# Altium schematic sheet 06_fmu_mcu3.SchDoc(06_fmu_mcu3)
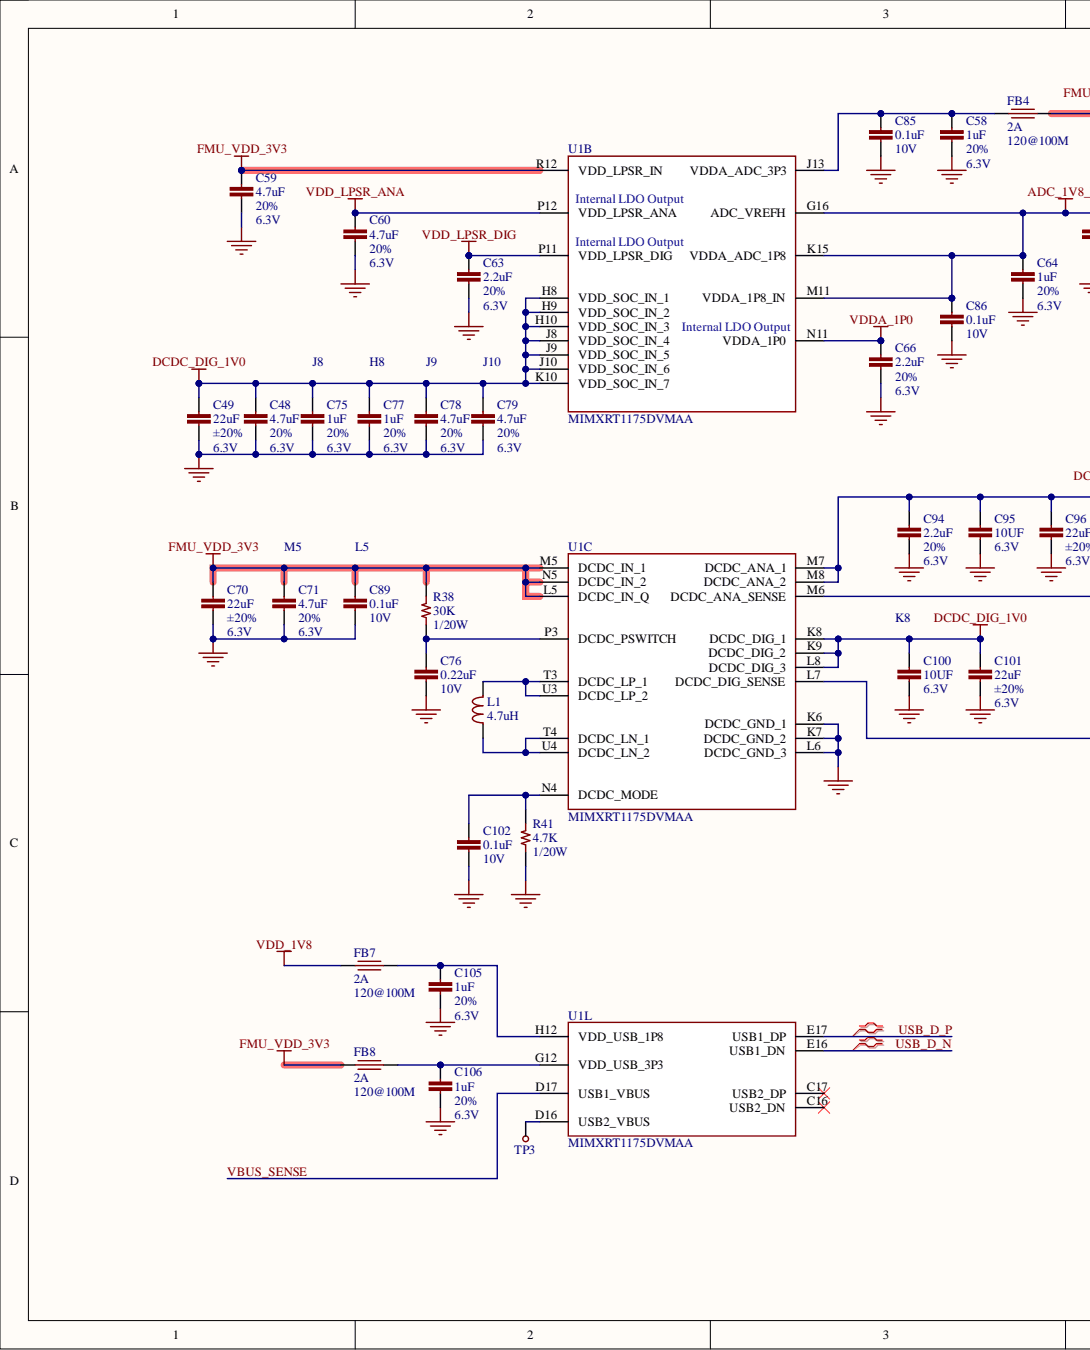
[diagram p0: 06_fmu_mcu3.SchDoc, left]
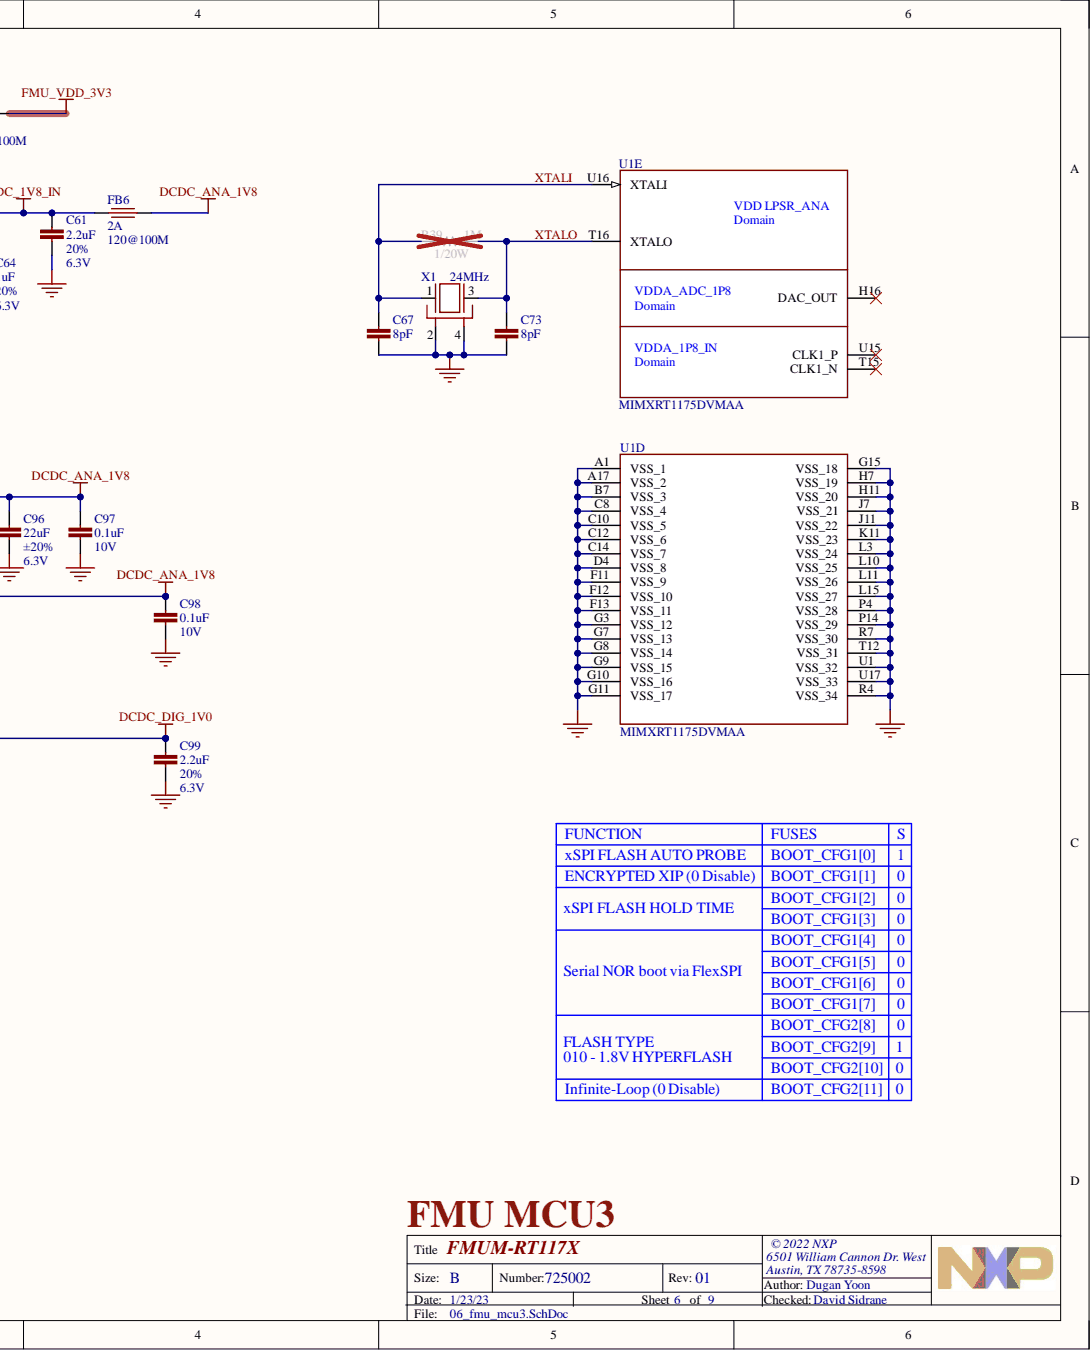
[diagram p1: 06_fmu_mcu3.SchDoc, right]

## Parts
C48  value 4.7uF  Tolerance: 20%  Voltage - Rated: 6.3V  [CAPC60X30X33L15N]  (hidden comment: CAP CER 4.7uF 20% 10V X5R 0201)
C49  value 22uF  Tolerance: ±20%  Voltage - Rated: 6.3V  [CAPC100X50X56L25N_NO_SILK]  (hidden comment: CAP CER 22UF 20% 6.3V X5R 0402)
C58  value 1uF  Tolerance: 20%  Voltage - Rated: 6.3V  [CAPC60X30X33L15N]  (hidden comment: CAP CER 1uF 20% 10V X5R 0201)
C59  value 4.7uF  Tolerance: 20%  Voltage - Rated: 6.3V  [CAPC60X30X33L15N]  (hidden comment: CAP CER 4.7uF 20% 10V X5R 0201)
C60  value 4.7uF  Tolerance: 20%  Voltage - Rated: 6.3V  [CAPC60X30X33L15N]  (hidden comment: CAP CER 4.7uF 20% 10V X5R 0201)
C61  value 2.2uF  Tolerance: 20%  Voltage - Rated: 6.3V  [CAPC60X30X33L15N]  (hidden comment: CAP CER 2.2uF 20% 10V X5R 0201)
C63  value 2.2uF  Tolerance: 20%  Voltage - Rated: 6.3V  [CAPC60X30X33L15N]  (hidden comment: CAP CER 2.2uF 20% 10V X5R 0201)
C64  value 1uF  Tolerance: 20%  Voltage - Rated: 6.3V  [CAPC60X30X33L15N]  (hidden comment: CAP CER 1uF 20% 10V X5R 0201)
C66  value 2.2uF  Tolerance: 20%  Voltage - Rated: 6.3V  [CAPC60X30X33L15N]  (hidden comment: CAP CER 2.2uF 20% 10V X5R 0201)
C67  value 8pF  [CAPC60X30X33L15N]  (hidden comment: CAP CER 8PF +/-0.5PF 50V COG/NPO 0201)
C70  value 22uF  Tolerance: ±20%  Voltage - Rated: 6.3V  [CAPC100X50X56L25N_NO_SILK]  (hidden comment: CAP CER 22UF 20% 6.3V X5R 0402)
C71  value 4.7uF  Tolerance: 20%  Voltage - Rated: 6.3V  [CAPC60X30X33L15N]  (hidden comment: CAP CER 4.7uF 20% 10V X5R 0201)
C73  value 8pF  [CAPC60X30X33L15N]  (hidden comment: CAP CER 8PF +/-0.5PF 50V COG/NPO 0201)
C75  value 1uF  Tolerance: 20%  Voltage - Rated: 6.3V  [CAPC60X30X33L15N]  (hidden comment: CAP CER 1uF 20% 10V X5R 0201)
C76  value 0.22uF  Voltage - Rated: 10V  [CAPC60X30X33L15N]  (hidden comment: CAP CER 0.22uF 10% 10V X5R 0201)
C77  value 1uF  Tolerance: 20%  Voltage - Rated: 6.3V  [CAPC60X30X33L15N]  (hidden comment: CAP CER 1uF 20% 10V X5R 0201)
C78  value 4.7uF  Tolerance: 20%  Voltage - Rated: 6.3V  [CAPC60X30X33L15N]  (hidden comment: CAP CER 4.7uF 20% 10V X5R 0201)
C79  value 4.7uF  Tolerance: 20%  Voltage - Rated: 6.3V  [CAPC60X30X33L15N]  (hidden comment: CAP CER 4.7uF 20% 10V X5R 0201)
C85  value 0.1uF  Voltage - Rated: 10V  [CAPC60X30X33L15N]  (hidden comment: CAP CER 0.1uF 20% 10V X5R 0201)
C86  value 0.1uF  Voltage - Rated: 10V  [CAPC60X30X33L15N]  (hidden comment: CAP CER 0.1uF 20% 10V X5R 0201)
C89  value 0.1uF  Voltage - Rated: 10V  [CAPC60X30X33L15N]  (hidden comment: CAP CER 0.1uF 20% 10V X5R 0201)
C94  value 2.2uF  Tolerance: 20%  Voltage - Rated: 6.3V  [CAPC60X30X33L15N]  (hidden comment: CAP CER 2.2uF 20% 10V X5R 0201)
C95  value 10UF  Voltage - Rated: 6.3V  [CAPC100X50X56L25N_NO_SILK]  (hidden comment: CAP CER 10UF 20% 6.3V X5R 0402)
C96  value 22uF  Tolerance: ±20%  Voltage - Rated: 6.3V  [CAPC100X50X56L25N_NO_SILK]  (hidden comment: CAP CER 22UF 20% 6.3V X5R 0402)
C97  value 0.1uF  Voltage - Rated: 10V  [CAPC60X30X33L15N]  (hidden comment: CAP CER 0.1uF 20% 10V X5R 0201)
C98  value 0.1uF  Voltage - Rated: 10V  [CAPC60X30X33L15N]  (hidden comment: CAP CER 0.1uF 20% 10V X5R 0201)
C99  value 2.2uF  Tolerance: 20%  Voltage - Rated: 6.3V  [CAPC60X30X33L15N]  (hidden comment: CAP CER 2.2uF 20% 10V X5R 0201)
C100  value 10UF  Voltage - Rated: 6.3V  [CAPC100X50X56L25N_NO_SILK]  (hidden comment: CAP CER 10UF 20% 6.3V X5R 0402)
C101  value 22uF  Tolerance: ±20%  Voltage - Rated: 6.3V  [CAPC100X50X56L25N_NO_SILK]  (hidden comment: CAP CER 22UF 20% 6.3V X5R 0402)
C102  value 0.1uF  Voltage - Rated: 10V  [CAPC60X30X33L15N]  (hidden comment: CAP CER 0.1uF 20% 10V X5R 0201)
C105  value 1uF  Tolerance: 20%  Voltage - Rated: 6.3V  [CAPC60X30X33L15N]  (hidden comment: CAP CER 1uF 20% 10V X5R 0201)
C106  value 1uF  Tolerance: 20%  Voltage - Rated: 6.3V  [CAPC60X30X33L15N]  (hidden comment: CAP CER 1uF 20% 10V X5R 0201)
FB4  value 120@100M  Current: 2A  [BEADC100X50X55L25]  (hidden comment: IND FERRITE 120@100M 2A 0402)
FB6  value 120@100M  Current: 2A  [BEADC100X50X55L25]  (hidden comment: IND FERRITE 120@100M 2A 0402)
FB7  value 120@100M  Current: 2A  [BEADC100X50X55L25]  (hidden comment: IND FERRITE 120@100M 2A 0402)
FB8  value 120@100M  Current: 2A  [BEADC100X50X55L25]  (hidden comment: IND FERRITE 120@100M 2A 0402)
L1  value 4.7uH  [INDDFN2_400X370X120L95X330N]  (hidden comment: IND 4.7UH 20% 1.55A 91 mOHM SMD)
R38  value 30K  Power: 1/20W  [RESC60X30X33L15N]  (hidden comment: RES SMD 30K OHM 1% 1/20W 0201)
R39  value 1M  Power: 1/20W  [RESC60X30X33L15N]  (hidden comment: RES SMD 1M OHM 1% 1/20W 0201)
R41  value 4.7K  Power: 1/20W  [RESC60X30X33L15N]  (hidden comment: RES SMD 4.7K OHM 1% 1/20W 0201)
TP3  TESTPOINT PAD NANO SMD  [TEST_PAD_0.3MM]  (value not drawn)  (no BOM)
U1  Manufacturer Part Number: MIMXRT1175DVMAA  [BGA289CP80_17X17_1400X1400X152B43N]  (hidden comment: IC MCU 32BIT EXT MEM 800MHz 289MAPBGA)  (parts B,C,D,E,L)
X1  Frequency: 24MHz  Load Capacitance: 8pF  [XTALDFN4_160X120X40L55X45N]  (hidden comment: CRYSTAL 24.0000MHZ 8PF 10PPM SMD)

## Nets
ADC_1V8_IN: C61.1 C64.1 C86.1 FB6.1 U1.G16(ADC_VREFH) U1.K15(VDDA_ADC_1P8) U1.M11(VDDA_1P8_IN)
DCDC_ANA_1V8: C94.1 C95.1 C96.1 C97.1 C98.1 FB6.2 U1.M6(DCDC_ANA_SENSE) U1.M7(DCDC_ANA_1) U1.M8(DCDC_ANA_2)
DCDC_DIG_1V0: C48.1 C49.1 C75.1 C77.1 C78.1 C79.1 C99.1 C100.1 C101.1 U1.H8(VDD_SOC_IN_1) U1.H9(VDD_SOC_IN_2) U1.H10(VDD_SOC_IN_3) U1.J8(VDD_SOC_IN_4) U1.J9(VDD_SOC_IN_5) U1.J10(VDD_SOC_IN_6) U1.K8(DCDC_DIG_1) U1.K9(DCDC_DIG_2) U1.K10(VDD_SOC_IN_7) U1.L7(DCDC_DIG_SENSE) U1.L8(DCDC_DIG_3)
FMU_VDD_3V3: C59.1 C70.1 C71.1 C89.1 FB4.2 FB8.1 R38.1 U1.L5(DCDC_IN_Q) U1.M5(DCDC_IN_1) U1.N5(DCDC_IN_2) U1.R12(VDD_LPSR_IN)
GND: C48.2 C49.2 C58.2 C59.2 C60.2 C61.2 C63.2 C64.2 C66.2 C67.2 C70.2 C71.2 C73.2 C75.2 C76.2 C77.2 C78.2 C79.2 C85.2 C86.2 C89.2 C94.2 C95.2 C96.2 C97.2 C98.2 C99.2 C100.2 C101.2 C102.2 C105.2 C106.2 R41.2 U1.A1(VSS_1) U1.A17(VSS_2) U1.B7(VSS_3) U1.C8(VSS_4) U1.C10(VSS_5) U1.C12(VSS_6) U1.C14(VSS_7) U1.D4(VSS_8) U1.F11(VSS_9) U1.F12(VSS_10) U1.F13(VSS_11) U1.G3(VSS_12) U1.G7(VSS_13) U1.G8(VSS_14) U1.G9(VSS_15) U1.G10(VSS_16) U1.G11(VSS_17) U1.G15(VSS_18) U1.H7(VSS_19) U1.H11(VSS_20) U1.J7(VSS_21) U1.J11(VSS_22) U1.K6(DCDC_GND_1) U1.K7(DCDC_GND_2) U1.K11(VSS_23) U1.L3(VSS_24) U1.L6(DCDC_GND_3) U1.L10(VSS_25) U1.L11(VSS_26) U1.L15(VSS_27) U1.P4(VSS_28) U1.P14(VSS_29) U1.R4(VSS_34) U1.R7(VSS_30) U1.T12(VSS_31) U1.U1(VSS_32) U1.U17(VSS_33) X1.2 X1.4
VDD_1V8: FB7.1
VDD_LPSR_ANA: C60.1 U1.P12(VDD_LPSR_ANA)
VDD_LPSR_DIG: C63.1 U1.P11(VDD_LPSR_DIG)
VDDA_1P0: C66.1 U1.N11(VDDA_1P0)
USB_D_N: U1.E16(USB1_DN)
USB_D_P: U1.E17(USB1_DP)
VBUS_SENSE: U1.D17(USB1_VBUS)
XTALI: C67.1 R39.1 U1.U16(XTALI) X1.1
XTALO: C73.1 R39.2 U1.T16(XTALO) X1.3
NetC58_1: C58.1 C85.1 FB4.1 U1.J13(VDDA_ADC_3P3)
NetC76_1: C76.1 R38.2 U1.P3(DCDC_PSWITCH)
NetC102_1: C102.1 R41.1 U1.N4(DCDC_MODE)
NetC105_1: C105.1 FB7.2 U1.H12(VDD_USB_1P8)
NetC106_1: C106.1 FB8.2 U1.G12(VDD_USB_3P3)
NetL1_1: L1.1 U1.T3(DCDC_LP_1) U1.U3(DCDC_LP_2)
NetL1_2: L1.2 U1.T4(DCDC_LN_1) U1.U4(DCDC_LN_2)
NetTP3_1: TP3.1 U1.D16(USB2_VBUS)

Not connected: U1 C16(USB2_DN) C17(USB2_DP) H16(DAC_OUT) T15(CLK1_N) U15(CLK1_P)

## Library data of the parts
C48 C59 C60 C71 C78 C79 = CAP_4.7uF_6.3V_X5R_0201: Manufacturer: Murata Electronics; Manufacturer Part Number: GRM035R60J475ME15D; Category: Capacitors; Component Kind: Standard; ComponentLink1Description: https://www.digikey.com/en/products/detail/murata-electronics/GRM035R60J475ME15D/5877393; ComponentLink1URL: https://www.digikey.com/en/products/detail/murata-electronics/GRM035R60J475ME15D/5877393; Description: CAP CER 4.7uF 20% 10V X5R 0201; Operating Temperature: -55°C ~ 85°C; Packaging: Cut Tape (CT); Part Status: Active; Pricing 1: 1=0.24 (USD); Stock 1: 73400; Supplier 1: Digi-Key; Supplier Part Number 1: 490-13230-1-ND; Library Name: NscDG_Integrated_Library.SchLib
C49 C70 C96 C101 = CAP_22UF_6.3V_X5R_0402: Manufacturer: Samsung Electro-Mechanics; Manufacturer Part Number: CL05A226MQ5N6J8; Category: Capacitors; Component Kind: Standard; ComponentLink1Description: https://www.digikey.com/en/products/detail/samsung-electro-mechanics/CL05A226MQ5N6J8/7320595; ComponentLink1URL: https://www.digikey.com/en/products/detail/samsung-electro-mechanics/CL05A226MQ5N6J8/7320595; Description: CAP CER 22UF 20% 6.3V X5R 0402; Operating Temperature: -55°C ~ 85°C; Packaging: Cut Tape (CT); Part Status: Active; Pricing 1: 1=0.49 (USD); Stock 1: 965480; Supplier 1: Digi-Key; Supplier Part Number 1: 1276-7090-1-ND; Library Name: NscDG_Integrated_Library.SchLib
C58 C64 C75 C77 C105 C106 = CAP_1uF_6.3V_X5R_0201: Manufacturer: YAGEO; Manufacturer Part Number: CC0201MPX5R5BB105; Category: Capacitors; Component Kind: Standard; ComponentLink1Description: https://www.digikey.com/en/products/detail/yageo/CC0201MPX5R5BB105/11611316; ComponentLink1URL: https://www.digikey.com/en/products/detail/yageo/CC0201MPX5R5BB105/11611316; Description: CAP CER 1uF 20% 10V X5R 0201; Operating Temperature: -55°C ~ 85°C; Packaging: Cut Tape (CT); Part Status: Active; Pricing 1: 1=0.10 (USD); Stock 1: 20-2927; Supplier 1: Digi-Key; Supplier Part Number 1: 13-CC0201MPX5R5BB105CT-ND; Library Name: NscDG_Integrated_Library.SchLib
C61 C63 C66 C94 C99 = CAP_2.2uF_6.3V_X5R_0201: Manufacturer: Murata Electronics; Manufacturer Part Number: GRM033R60J225ME47D; Category: Capacitors; Component Kind: Standard; ComponentLink1Description: https://www.digikey.com/en/products/detail/murata-electronics/GRM033R60J225ME47D/5877388; ComponentLink1URL: https://www.digikey.com/en/products/detail/murata-electronics/GRM033R60J225ME47D/5877388; Description: CAP CER 2.2uF 20% 10V X5R 0201; Operating Temperature: -55°C ~ 85°C; Packaging: Cut Tape (CT); Part Status: Active; Pricing 1: 1=0.18 (USD); Stock 1: 9156088; Supplier 1: Digi-Key; Supplier Part Number 1: 490-13225-1-ND; Library Name: NscDG_Integrated_Library.SchLib
C67 C73 = CAP_8PF_50V_COG_NPO_0201: Manufacturer: Murata Electronics; Manufacturer Part Number: GRM0335C1H8R0DA01J; Tolerance: ±0.5pF; Category: Capacitors; Component Kind: Standard; ComponentLink1Description: https://www.digikey.com/en/products/detail/murata-electronics/GRM0335C1H8R0DA01J/4358517; ComponentLink1URL: https://www.digikey.com/en/products/detail/murata-electronics/GRM0335C1H8R0DA01J/4358517; Description: CAP CER 8PF +/-0.5PF 50V COG/NPO 0201; Operating Temperature: -55°C ~ 125°C; Packaging: Cut Tape (CT); Part Status: Active; Pricing 1: 1=0.10 (USD); Stock 1: 287286; Supplier 1: Digi-Key; Supplier Part Number 1: 490-13331-1-ND; Voltage - Rated: 50V; Library Name: NscDG_Integrated_Library.SchLib
C76 = CAP_0.22uF_10V_X5R_0201: Manufacturer: Murata Electronics; Manufacturer Part Number: GRM033R61A224KE90D; Category: Capacitors; Component Kind: Standard; ComponentLink1Description: https://www.digikey.com/en/products/detail/murata-electronics/GRM033R61A224KE90D/5027496; ComponentLink1URL: https://www.digikey.com/en/products/detail/murata-electronics/GRM033R61A224KE90D/5027496; Description: CAP CER 0.22uF 10% 10V X5R 0201; Operating Temperature: -55°C ~ 85°C; Packaging: Cut Tape (CT); Part Status: Active; Pricing 1: 1=0.10 (USD); Stock 1: 4690076; Supplier 1: Digi-Key; Supplier Part Number 1: 490-10411-1-ND; Tolerance: 10%; Library Name: NscDG_Integrated_Library.SchLib
C85 C86 C89 C97 C98 C102 = CAP_0.1uF_10V_X5R_0201: Manufacturer: Murata Electronics; Manufacturer Part Number: GRM033R61A104ME15D; Category: Capacitors; Component Kind: Standard; ComponentLink1Description: https://www.digikey.com/en/products/detail/murata-electronics/GRM033R61A104ME15D/2175191; ComponentLink1URL: https://www.digikey.com/en/products/detail/murata-electronics/GRM033R61A104ME15D/2175191; Description: CAP CER 0.1uF 20% 10V X5R 0201; Operating Temperature: -55°C ~ 85°C; Packaging: Cut Tape (CT); Part Status: Active; Pricing 1: 1=0.10 (USD); Stock 1: 12909013; Supplier 1: Digi-Key; Supplier Part Number 1: 490-5405-1-ND; Tolerance: 20%; Library Name: NscDG_Integrated_Library.SchLib
C95 C100 = CAP_10UF_20%_6.3V_X5R_0402: Manufacturer: Samsung Electro-Mechanics; Manufacturer Part Number: CL05A106MQ5NUNC; Category: Capacitors; Component Kind: Standard; ComponentLink1Description: https://www.digikey.com/product-detail/en/samsung-electro-mechanics/CL05A106MQ5NUNC/1276-1451-1-ND/3889537; ComponentLink1URL: https://www.digikey.com/product-detail/en/samsung-electro-mechanics/CL05A106MQ5NUNC/1276-1451-1-ND/3889537; Description: CAP CER 10UF 20% 6.3V X5R 0402; Operating Temperature: -55°C ~ 85°C; Packaging: Tape & Reel (TR); Part Status: Active; Pricing 1: 1=0.55 (USD); Ratings: -; Stock 1: 1715426; Supplier 1: Digi-Key; Supplier Part Number 1: 1276-1451-1-ND; Temperature Coefficient: X5R; Tolerance: ±20%; Library Name: NscDG_Integrated_Library.SchLib
FB4 FB6-FB8 = IND_FERRITE_120@120M_2A_0402: Manufacturer: Murata Electronics North America; Manufacturer Part Number: BLM15PX121SN1D; Category: Inductors; Component Kind: Standard; ComponentLink1Description: https://www.digikey.com/product-detail/en/murata-electronics/BLM15PX121SN1D/490-9656-1-ND/4934752; ComponentLink1URL: https://www.digikey.com/product-detail/en/murata-electronics/BLM15PX121SN1D/490-9656-1-ND/4934752; Description: IND FERRITE 120@100M 2A 0402; Operating Temperature: -55°C ~ 125°C; Packaging: Cut Tape (CT); Part Status: Active; Pricing 1: 1=0.10 (USD); Stock 1: 98122; Supplier 1: Digi-Key; Supplier Part Number 1: 490-9656-1-ND; Library Name: NscDG_Integrated_Library.SchLib
  FB7 FB8: Value: 120@100M
L1 = IND_4.7UH_20%_1.55A_SMD: Manufacturer: Würth Elektronik; Manufacturer Part Number: 74404041047; DCR: 91 mOhm; Category: Inductors; Component Kind: Standard; ComponentLink1Description: https://www.digikey.com/en/products/detail/w%C3%BCrth-elektronik/74404041047/5353045?s=N4IgTCBcDaIOwBYEAYUIIyriAugXyA; ComponentLink1URL: https://www.digikey.com/en/products/detail/w%C3%BCrth-elektronik/74404041047/5353045?s=N4IgTCBcDaIOwBYEAYUIIyriAugXyA; Current: 1.55A; Description: IND 4.7UH 20% 1.55A 91 mOHM SMD; Operating Temperature: -40°C ~ 125°C; Packaging: Cut Tape (CT); Part Status: Active; Pricing 1: 1=1.20 (USD); Stock 1: 16012; Supplier 1: DigiKey; Supplier Part Number 1: 732-7226-1-ND; Tolerance: ±20%; Library Name: NscDG_Integrated_Library.SchLib
R38 = RES_30K_1%_1/20W_0201: Manufacturer: Yageo; Manufacturer Part Number: RC0201FR-0730KL; Category: Resistors; Component Kind: Standard; ComponentLink1Description: https://www.digikey.com/en/products/detail/yageo/RC0201FR-0730KL/3202402; ComponentLink1URL: https://www.digikey.com/en/products/detail/yageo/RC0201FR-0730KL/3202402; Description: RES SMD 30K OHM 1% 1/20W 0201; Operating Temperature: -55°C ~ 125°C; Packaging: Cut Tape (CT); Part Status: Active; Pricing 1: 1=0.1, 10=0.014, 100=0.0056, 1000=0.00253, 2500=0.0022, 5000=0.00181 (USD); Stock 1: 428669; Supplier 1: Digi-Key; Supplier Part Number 1: YAG2281CT-ND; Tolerance: ±1%; Library Name: NscDG_Integrated_Library.SchLib
R39 = RES_1M_1%_1/20W_0201: Manufacturer: Yageo; Manufacturer Part Number: RC0201FR-071ML; Category: Resistors; Component Kind: Standard; ComponentLink1Description: https://www.digikey.com/en/products/detail/yageo/RC0201FR-071ML/3202390; ComponentLink1URL: https://www.digikey.com/en/products/detail/yageo/RC0201FR-071ML/3202390; Description: RES SMD 1M OHM 1% 1/20W 0201; Operating Temperature: -55°C ~ 125°C; Packaging: Cut Tape (CT); Part Status: Active; Pricing 1: 1=0.1, 10=0.014, 100=0.0056, 1000=0.00253, 2500=0.0022, 5000=0.00181 (USD); Stock 1: 1882211; Supplier 1: Digi-Key; Supplier Part Number 1: 311-1MMCT-ND; Tolerance: ±1%; Library Name: NscDG_Integrated_Library.SchLib
R41 = RES_4.7K_1%_1/20W_0201: Manufacturer: Yageo; Manufacturer Part Number: RC0201FR-074K7L; Category: Resistors; Component Kind: Standard; ComponentLink1Description: https://www.digikey.com/en/products/detail/yageo/RC0201FR-074K7L/1948894; ComponentLink1URL: https://www.digikey.com/en/products/detail/yageo/RC0201FR-074K7L/1948894; Description: RES SMD 4.7K OHM 1% 1/20W 0201; Operating Temperature: -55°C ~ 125°C; Packaging: Cut Tape (CT); Part Status: Active; Pricing 1: 1=0.1, 10=0.014, 100=0.0056, 1000=0.00253, 2500=0.0022, 5000=0.00181 (USD); Stock 1: 6201845; Supplier 1: Digi-Key; Supplier Part Number 1: 311-4.70KMCT-ND; Tolerance: ±1%; Library Name: NscDG_Integrated_Library.SchLib
TP3 = TESTPOINT_PAD_NANO_SMD: CATEGORY: Test and Measurement; Description: TESTPOINT PAD NANO SMD; Manufacturer: N/A; Library Name: NscDG_Integrated_Library.SchLib
U1 = IC_MIMXRT1175DVMAA_289MAPBGA: Manufacturer: NXP USA; Category: Integrated Circuits (ICs); Component Kind: Standard; ComponentLink1Description: https://www.digikey.com/en/products/detail/nxp-usa-inc/MIMXRT1062DVL6B/13635843?s=N4IgTCBcDaILIEk4A0BKAVAjABgGxgBEA1AGVwCEQBdAXyA; ComponentLink1URL: https://www.digikey.com/en/products/detail/nxp-usa-inc/MIMXRT1175DVMAA/13536094?s=N4IgTCBcDaIJIFkAaAlAKgRgwdgKwBEA1BAQRJAF0BfIA; Description: IC MCU 32BIT EXT MEM 800MHz 289MAPBGA; Operating Temperature: 0C to 95C; Packaging: Tray; Part Status: Active; Pricing 1: 1=17.93 (USD); Stock 1: 0; Supplier 1: DigiKey; Supplier Part Number 1: 568-MIMXRT1175DVMAA-ND; Library Name: NscDG_Integrated_Library.SchLib
X1 = XTAL_24MHZ_8PF_10PPM_4-SMD: Manufacturer: Würth Elektronik; Manufacturer Part Number: 830108160801; Frequency Stability: ±20ppm; Category: Crystals, Oscillators, Resonators; Component Kind: Standard; ComponentLink1Description: https://www.digikey.com/products/en?keywords=1253-1745-1-ND; ComponentLink1URL: https://www.digikey.com/en/products/detail/w%C3%BCrth-elektronik/830108160801/13900373; Description: CRYSTAL 24.0000MHZ 8PF 10PPM SMD; Frequency Tolerance: ±10ppm; Operating Temperature: -40°C ~ 85°C; Packaging: Cut Tape (CT); Part Status: Active; Pricing 1: 1=0.78 (USD); Stock 1: 2698; Supplier 1: Digi-Key; Supplier Part Number 1: 1923-830108160801CT-ND; Library Name: NscDG_Integrated_Library.SchLib
